# Altium schematic sheet AD9834.SchDoc(AD9834)
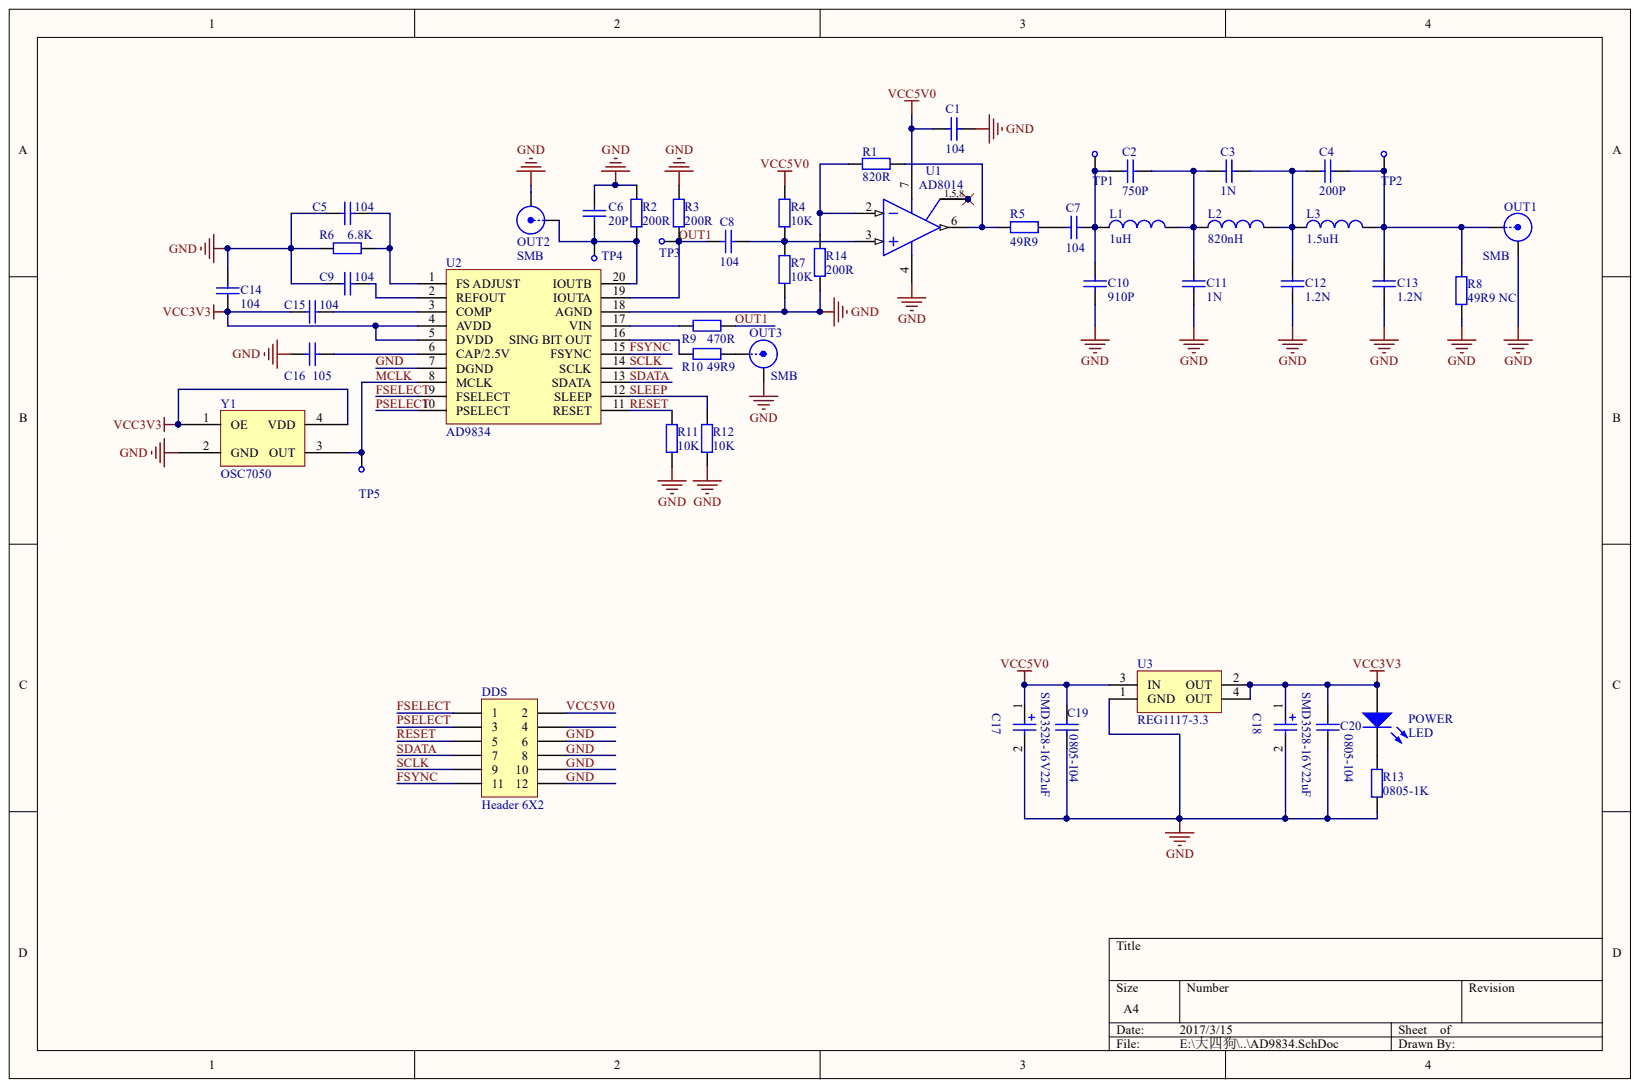
[diagram p0: AD9834.SchDoc]

## Parts
C1  104  [CAP-SMD0603]
C2  750P  [CAP-SMD0603]
C3  1N  [CAP-SMD0603]
C4  200P  [CAP-SMD0603]
C5  104  [CAP-SMD0603]
C6  20P  [CAP-SMD0603]
C7  104  [CAP-SMD0603]
C8  104  [CAP-SMD0603]
C9  104  [CAP-SMD0603]
C10  910P  [CAP-SMD0603]
C11  1N  [CAP-SMD0603]
C12  1.2N  [CAP-SMD0603]
C13  1.2N  [CAP-SMD0603]
C14  104  [CAP-SMD0603]
C15  104  [CAP-SMD0603]
C16  105  [CAP-SMD0603]
C17  SMD3528-16V22uF  [CAP-SMD3528(B)]
C18  SMD3528-16V22uF  [CAP-SMD3528(B)]
C19  0805-104  [SMD0805L]
C20  0805-104  [SMD0805L]
DDS  Header 6X2  [HEADER6X2]
L1  1uH  [SMD0603]
L2  820nH  [SMD0603]
L3  1.5uH  [SMD0603]
OUT1  SMB  [SMB_V-RJ45]
OUT2  SMB  [SMB_V-RJ45]
OUT3  SMB  [SMB_V-RJ45]
POWER  LED  [0805_led]
R1  820R  [SMD0603]
R2  200R  [SMD0603]
R3  200R  [SMD0603]
R4  10K  [SMD0603]
R5  49R9  [SMD0603]
R6  6.8K  [SMD0603]
R7  10K  [SMD0603]
R8  49R9 NC  [SMD0603]
R9  470R  [SMD0603]
R10  49R9  [SMD0603]
R11  10K  [SMD0603]
R12  10K  [SMD0603]
R13  0805-1K  [SMD0805L]
R14  200R  [SMD0603]
TP1  TP  [TestPoint_DIP]
TP2  TP  [TestPoint_DIP]
TP3  TP  [TestPoint_DIP]
TP4  TP  [TestPoint_DIP]
TP5  TP  [TestPoint_DIP]
U1  AD8014  [SOP8]
U2  AD9834  [TSSOP20]
U3  REG1117-3.3  [AMS1117]
Y1  OSC7050  [OSC7050]

## Nets
VCC3V3: C14.2 C15.2 C18.1 C20.2 POWER.1 U2.4(AVDD) U2.5(DVDD) U3.2(OUT) U3.4(OUT) Y1.1(OE) Y1.4(VDD)
FSELECT: DDS.1 U2.9(FSELECT)
FSYNC: DDS.11 U2.15(FSYNC)
GND: C1.1 C5.1 C6.2 C9.2 C10.1 C11.1 C12.1 C13.1 C14.1 C16.2 C17.2 C18.2 C19.1 C20.1 DDS.6 DDS.8 DDS.10 DDS.12 OUT1.2 OUT2.2 OUT3.2 R2.2 R3.2 R6.1 R7.1 R8.1 R11.2 R12.2 R13.1 R14.2 U1.4 U2.7(DGND) U2.18(AGND) U3.1(GND) Y1.2(GND)
MCLK: TP5.1 U2.8(MCLK) Y1.3(OUT)
OUT1: C8.1 R3.1 R9.1 TP3.1 U2.19(IOUTA)
PSELECT: DDS.3 U2.10(PSELECT)
RESET: DDS.5 R11.1 U2.11(RESET)
SCLK: DDS.9 U2.14(SCLK)
SDATA: DDS.7 U2.13(SDATA)
SLEEP: R12.1 U2.12(SLEEP)
VCC5V0: C1.2 C17.1 C19.2 DDS.2 R4.2 U1.7 U3.3(IN)
NetC2_1: C2.1 C7.2 C10.2 L1.1 TP1.1
NetC2_2: C2.2 C3.1 C11.2 L1.2 L2.1
NetC3_2: C3.2 C4.1 C12.2 L2.2 L3.1
NetC4_2: C4.2 C13.2 L3.2 OUT1.1 R8.2 TP2.1
NetC5_2: C5.2 R6.2 U2.1(FS_ADJUST)
NetC6_1: C6.1 OUT2.1 R2.1 TP4.1 U2.20(IOUTB)
NetC7_1: C7.1 R5.1
NetC8_2: C8.2 R4.1 R7.2 U1.3
NetC9_1: C9.1 U2.2(REFOUT)
NetC15_1: C15.1 U2.3(COMP)
NetC16_1: C16.1 U2.6(CAP/2.5V)
NetOUT3_1: OUT3.1 R10.1
NetPOWER_2: POWER.2 R13.2
NetR1_1: R1.1 R5.2 U1.6
NetR1_2: R1.2 R14.1 U1.2
NetR9_2: R9.2 U2.17(VIN)
NetR10_2: R10.2 U2.16(SING_BIT_OUT)
NetU1_1: U1.1 U1.5 U1.8

Not connected: DDS 4

## Library data of the parts
C1-C16 C19 C20 = Cap: Capacitor; Manufacturer: Generic Components; Class: Passive; Value: 100pF; Component Kind: Standard; Published: 08/06/2000; SubClass: Capacitor; Library Name: HV9006_MEASUREBOARD.SCHLIB; Publisher: Altium Hobart Technology Centre
C17 C18 = Cap Pol1: Polarized Capacitor (Radial); Manufacturer: Generic Components; Class: Passive; Value: 100pF; Component Kind: Standard; Published: 08/06/2000; SubClass: Capacitor; Library Name: HV9006_MEASUREBOARD.SCHLIB; Publisher: Altium Hobart Technology Centre
DDS = Header 6X2: Header, 6-Pin, Dual row; Component Kind: Standard; LatestRevisionDate: 17-Jul-2002; LatestRevisionNote: Re-released for DXP Platform.; Library Name: Miscellaneous Connectors.SchLib; Signal Integrity: Connector; Publisher: Altium Limited
L1-L3 = Inductor: Manufacturer: Generic Components; Class: Passive; Value: 10mH; Component Kind: Standard; Description: Inductor; Published: 08/06/2000; SubClass: Inductor; Library Name: HV9006_MEASUREBOARD.SCHLIB; Publisher: Altium Hobart Technology Centre
OUT1-OUT3 = SMB: SMB Straight Connector; Component Kind: Standard; LatestRevisionDate: 17-Jul-2002; LatestRevisionNote: Re-released for DXP Platform.; Library Name: Miscellaneous Connectors.IntLib; Signal Integrity: Connector; Publisher: Altium Limited
POWER = LED1: Typical RED GaAs LED; Component Kind: Standard; LatestRevisionDate: 08-Jul-2005; LatestRevisionNote: Mech Layer 1 removed.; Library Name: Miscellaneous Devices.IntLib; PackageDescription: LED; 2 Leads; PackageReference: LED-1; Published: 8-Jun-2000; Simulation: LED1; Publisher: Altium Limited
R1-R14 = Res2: Resistor; Manufacturer: Generic Components; Class: Passive; Value: 4K7; Component Kind: Standard; Published: 08/06/2000; SubClass: Resistor; Library Name: HV9006_MEASUREBOARD.SCHLIB; Publisher: Altium Hobart Technology Centre
TP1-TP5 = TestPoint: Component Kind: Standard; Description: TestPoint; Library Name: CrazyLib.SchLib
U1 = OPA648U: Ultra-Wideband Current Feedback Operational Amplifier; Text Field6: Pins 1, 5 and 8 are No internal Connection (NC) pins.; Text Field7: Published 8-Jun-2000, Modified 10-July-2000: SIM Model Added.; Component Kind: Standard; Library Name: BB Operational Amplifier Sch.SCHLIB
U3 = REG1117-3.3: 800mA and 1A Low Dropout Positive Regulator 2.85V, 3.3V, 5V, and Adjustable; Component Kind: Standard; ComponentLink1Description: Manufacturer Link; ComponentLink1URL: http://www.ti.com/; ComponentLink2Description: Datasheet; ComponentLink2URL: http://www-s.ti.com/sc/ds/REG1117.pdf; DatasheetVersion: Sep-1997; LatestRevisionDate: 17-Jul-2002; LatestRevisionNote: Re-released for DXP Platform.; Library Name: BB Power Mgt Voltage Regulator.IntLib; PackageDescription: SOT-223/SC-73; 4 Leads; Body 6.7 x 7.3 mm, inc. leads (LxW max); PackageReference: ZZ311; PackageVersion: revC; Published: 8-Jun-2000; Publisher: Altium Limited
U2 = AD9834: Component Kind: Standard; Description: AD9834; Library Name: CrazyLib.SchLib
Y1 = OSC7050: Component Kind: Standard; Library Name: Integrated_Library1.IntLib
